# Altium schematic sheet Connect.SchDoc(Connect)
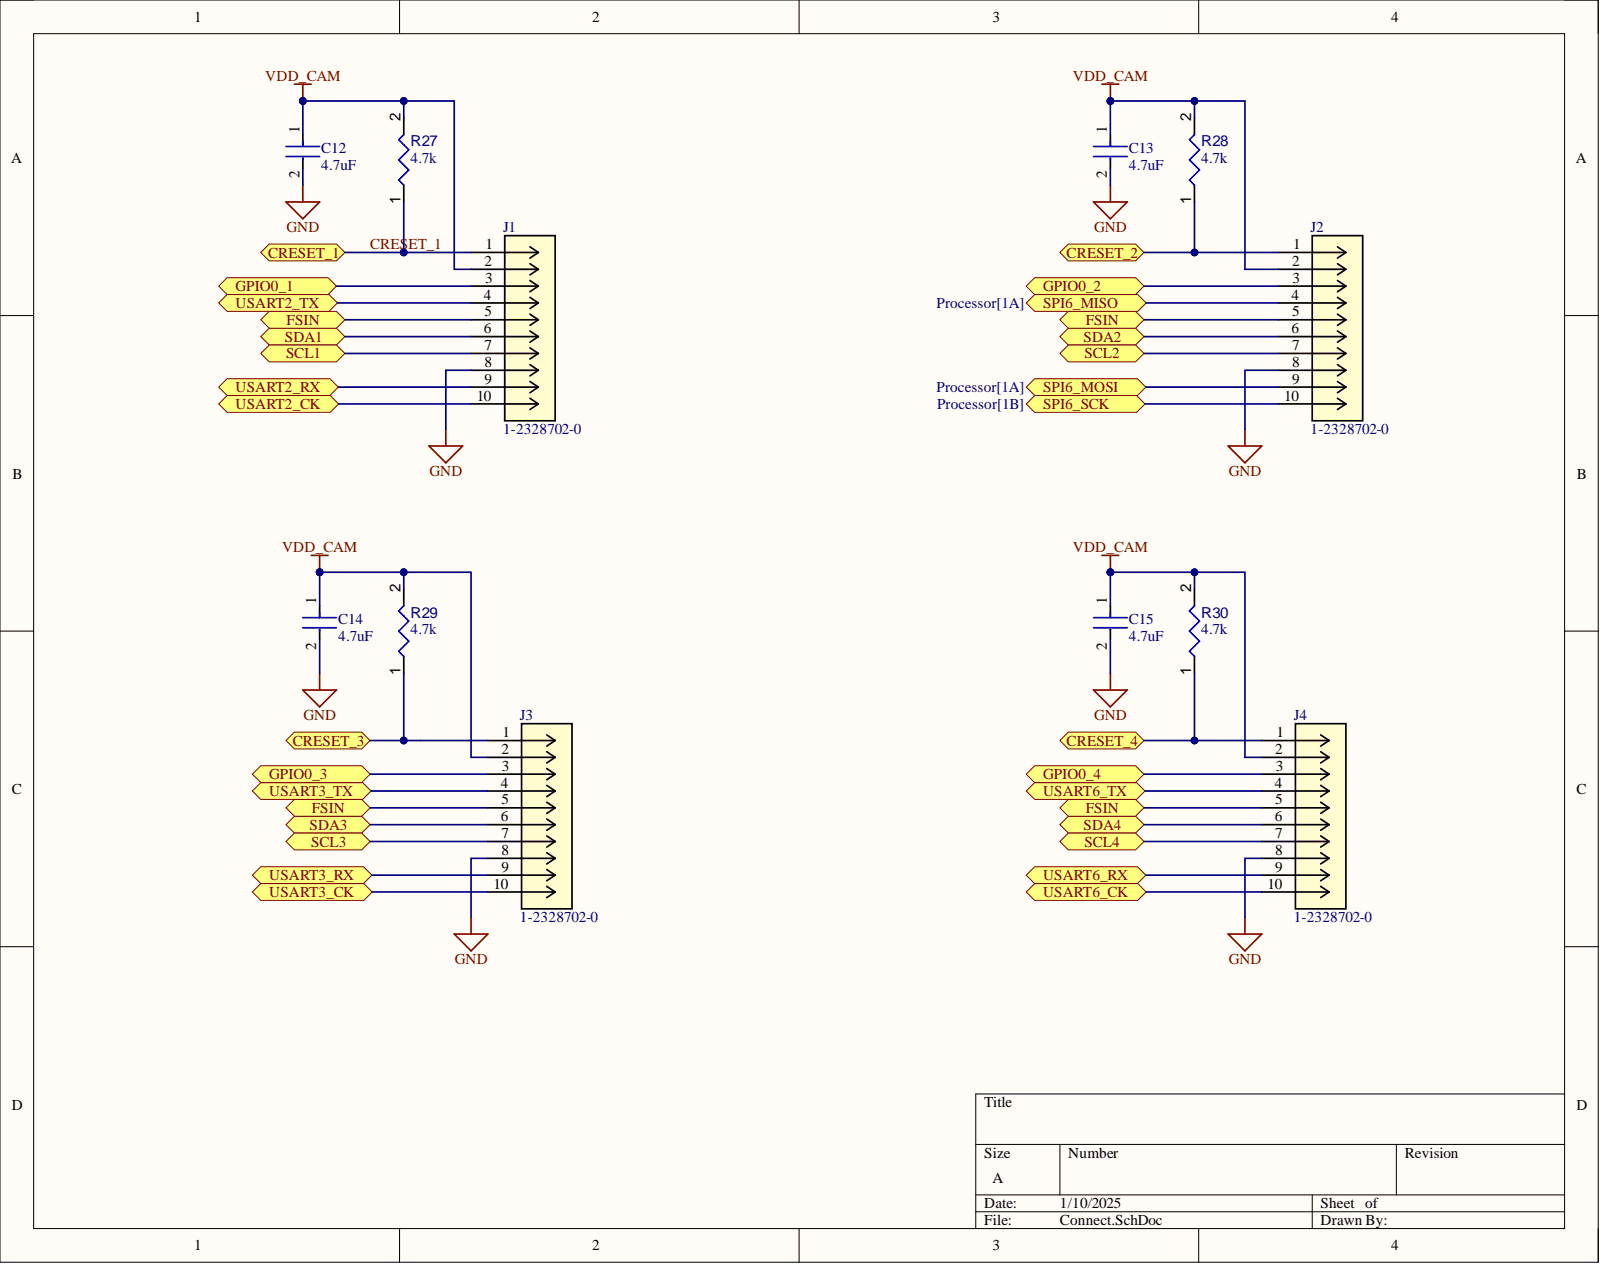
[diagram p0: Connect.SchDoc]

## Parts
C12  4.7uF
C13  4.7uF
C14  4.7uF
C15  4.7uF
J1  1-2328702-0
J2  1-2328702-0
J3  1-2328702-0
J4  1-2328702-0
R27  value 4.7k  (hidden comment: Resistor 4K7  +/-1 % 0402 63 mW)
R28  value 4.7k  (hidden comment: Resistor 4K7  +/-1 % 0402 63 mW)
R29  value 4.7k  (hidden comment: Resistor 4K7  +/-1 % 0402 63 mW)
R30  value 4.7k  (hidden comment: Resistor 4K7  +/-1 % 0402 63 mW)

## Ports
CRESET_1, CRESET_2, CRESET_3, CRESET_4, FSIN, GPIO0_1, GPIO0_2, GPIO0_3, GPIO0_4, SCL1, SCL2, SCL3, SCL4, SDA1, SDA2, SDA3, SDA4, SPI6_MISO, SPI6_MOSI, SPI6_SCK, USART2_CK, USART2_RX, USART2_TX, USART3_CK, USART3_RX, USART3_TX, USART6_CK, USART6_RX, USART6_TX

## Nets
GND: C12.2 C13.2 C14.2 C15.2 J1.8 J2.8 J3.8 J4.8
VDD_CAM: C12.1 C13.1 C14.1 C15.1 J1.2 J2.2 J3.2 J4.2 R27.2 R28.2 R29.2 R30.2
CRESET_1: J1.1 R27.1 ; port CRESET_1
CRESET_2: J2.1 R28.1 ; port CRESET_2
CRESET_3: J3.1 R29.1 ; port CRESET_3
CRESET_4: J4.1 R30.1 ; port CRESET_4
FSIN: J1.5 J2.5 J3.5 J4.5 ; port FSIN
GPIO0_1: J1.3 ; port GPIO0_1
GPIO0_2: J2.3 ; port GPIO0_2
GPIO0_3: J3.3 ; port GPIO0_3
GPIO0_4: J4.3 ; port GPIO0_4
SCL1: J1.7 ; port SCL1
SCL2: J2.7 ; port SCL2
SCL3: J3.7 ; port SCL3
SCL4: J4.7 ; port SCL4
SDA1: J1.6 ; port SDA1
SDA2: J2.6 ; port SDA2
SDA3: J3.6 ; port SDA3
SDA4: J4.6 ; port SDA4
SPI6_MISO: J2.4 ; port SPI6_MISO
SPI6_MOSI: J2.9 ; port SPI6_MOSI
SPI6_SCK: J2.10 ; port SPI6_SCK
USART2_CK: J1.10 ; port USART2_CK
USART2_RX: J1.9 ; port USART2_RX
USART2_TX: J1.4 ; port USART2_TX
USART3_CK: J3.10 ; port USART3_CK
USART3_RX: J3.9 ; port USART3_RX
USART3_TX: J3.4 ; port USART3_TX
USART6_CK: J4.10 ; port USART6_CK
USART6_RX: J4.9 ; port USART6_RX
USART6_TX: J4.4 ; port USART6_TX

## Library data of the parts
C12-C15 = CMP-2000-05997-2: CAP CER 4.7UF 10V X5R 0402; Dielectric Material: Ceramic; Tolerance: 10%; Capacitance: 4.7uF; Case Code (Imperial): 0402; Case Code (Metric): 1005; Case/Package: 0402; Component Kind: Standard; Dielectric: X5R; Lead Free: Lead Free; Length: 0.039inch; Library Name: Altium Content Vault; Max Operating Temperature: 85°C; Min Operating Temperature: -55°C; Mount: Surface Mount; Package Quantity: 10000; Packaging: Cut Tape; REACH SVHC: No SVHC; RoHS Compliant: Yes; Series: C; Thickness: 0.022inch; Voltage Rating: 10V; Voltage Rating (DC): 10V; Width: 0.02inch
J1-J4 = 1-2328702-0: No Description Available; Mfr_Name: TE Connectivity; Component Kind: Standard; Library Name: 1-2328702-0.SchLib; RefDes: RefDes; Type: Type
R27-R30 = CMP-009-00158-3: Chip Resistor, 4,7 kOhms, +/-1 %, 63 mW, -55 to 155 degC, 0402; Power: 63mW; Tolerance: 1%; Case/Package: 0402; Component Kind: Standard; Max Operating Temperature: 155°C; Min Operating Temperature: -55°C; Pins: 2; Library Name: Openwater
